# Altium schematic sheet Sheet1.SchDoc
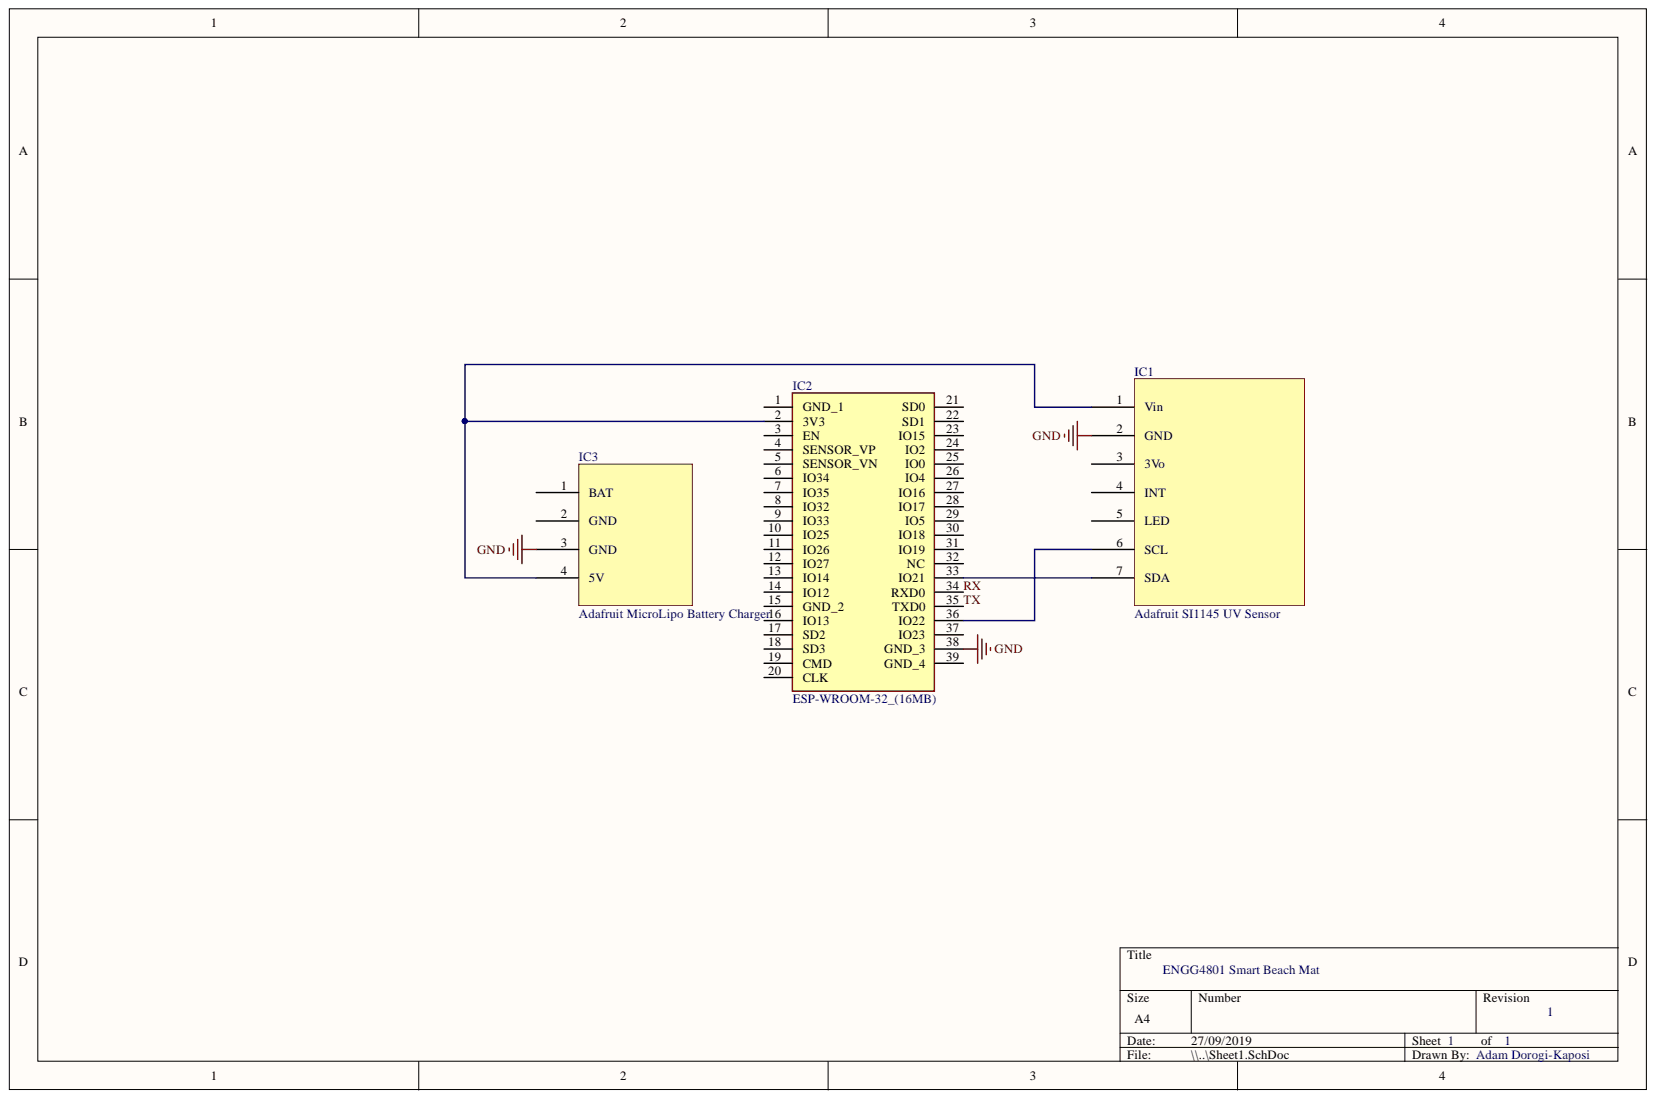
[diagram p0: Sheet1.SchDoc]

## Parts
IC1  Adafruit SI1145 UV Sensor  [SI1145]
IC2  ESP-WROOM-32_(16MB)  [ESP32WROOM32D]
IC3  Adafruit MicroLipo Battery Charger  [MicroLipo]

## Nets
GND: IC1.2(GND) IC2.38(GND_3) IC3.3(GND)
RX: IC2.34(RXD0)
TX: IC2.35(TXD0)
NetIC1_1: IC1.1(Vin) IC2.2(3V3) IC3.4(5V)
NetIC1_6: IC1.6(SCL) IC2.36(IO22)
NetIC1_7: IC1.7(SDA) IC2.33(IO21)

Not connected: IC1 3(3Vo) 4(INT) 5(LED); IC2 1(GND_1) 3(EN) 4(SENSOR_VP) 5(SENSOR_VN) 6(IO34) 7(IO35) 8(IO32) 9(IO33) 10(IO25) 11(IO26) 12(IO27) 13(IO14) 14(IO12) 15(GND_2) 16(IO13) 17(SD2) 18(SD3) 19(CMD) 20(CLK) 21(SD0) 22(SD1) 23(IO15) 24(IO2) 25(IO0) 26(IO4) 27(IO16) 28(IO17) 29(IO5) 30(IO18) 31(IO19) 32(NC) 37(IO23) 39(GND_4); IC3 1(BAT) 2(GND)

## Library data of the parts
IC1 = SI1145: Component Kind: Standard; Description: Adafruit SI1145 UV Sensor; Library Name: Schlib1.SchLib
IC2 = ESP-WROOM-32_(16MB): Integrated Circuit; Manufacturer_Name: Espressif; Manufacturer_Part_Number: ESP-WROOM-32 (16MB); Component Kind: Standard; Datasheet Link: https://www.mouser.com/datasheet/2/891/esp-wroom-32_datasheet_en-1365813.pdf; Height: 3.1mm; Library Name: SamacSys.SchLib; Mouser Part Number: 356-ESPWROOM3216MB
IC3 = MicroLipo: Component Kind: Standard; Description: Adafruit MicroLipo Battery Charger; Library Name: Schlib1.SchLib
